# Altium schematic sheet MCU-BLE.SchDoc
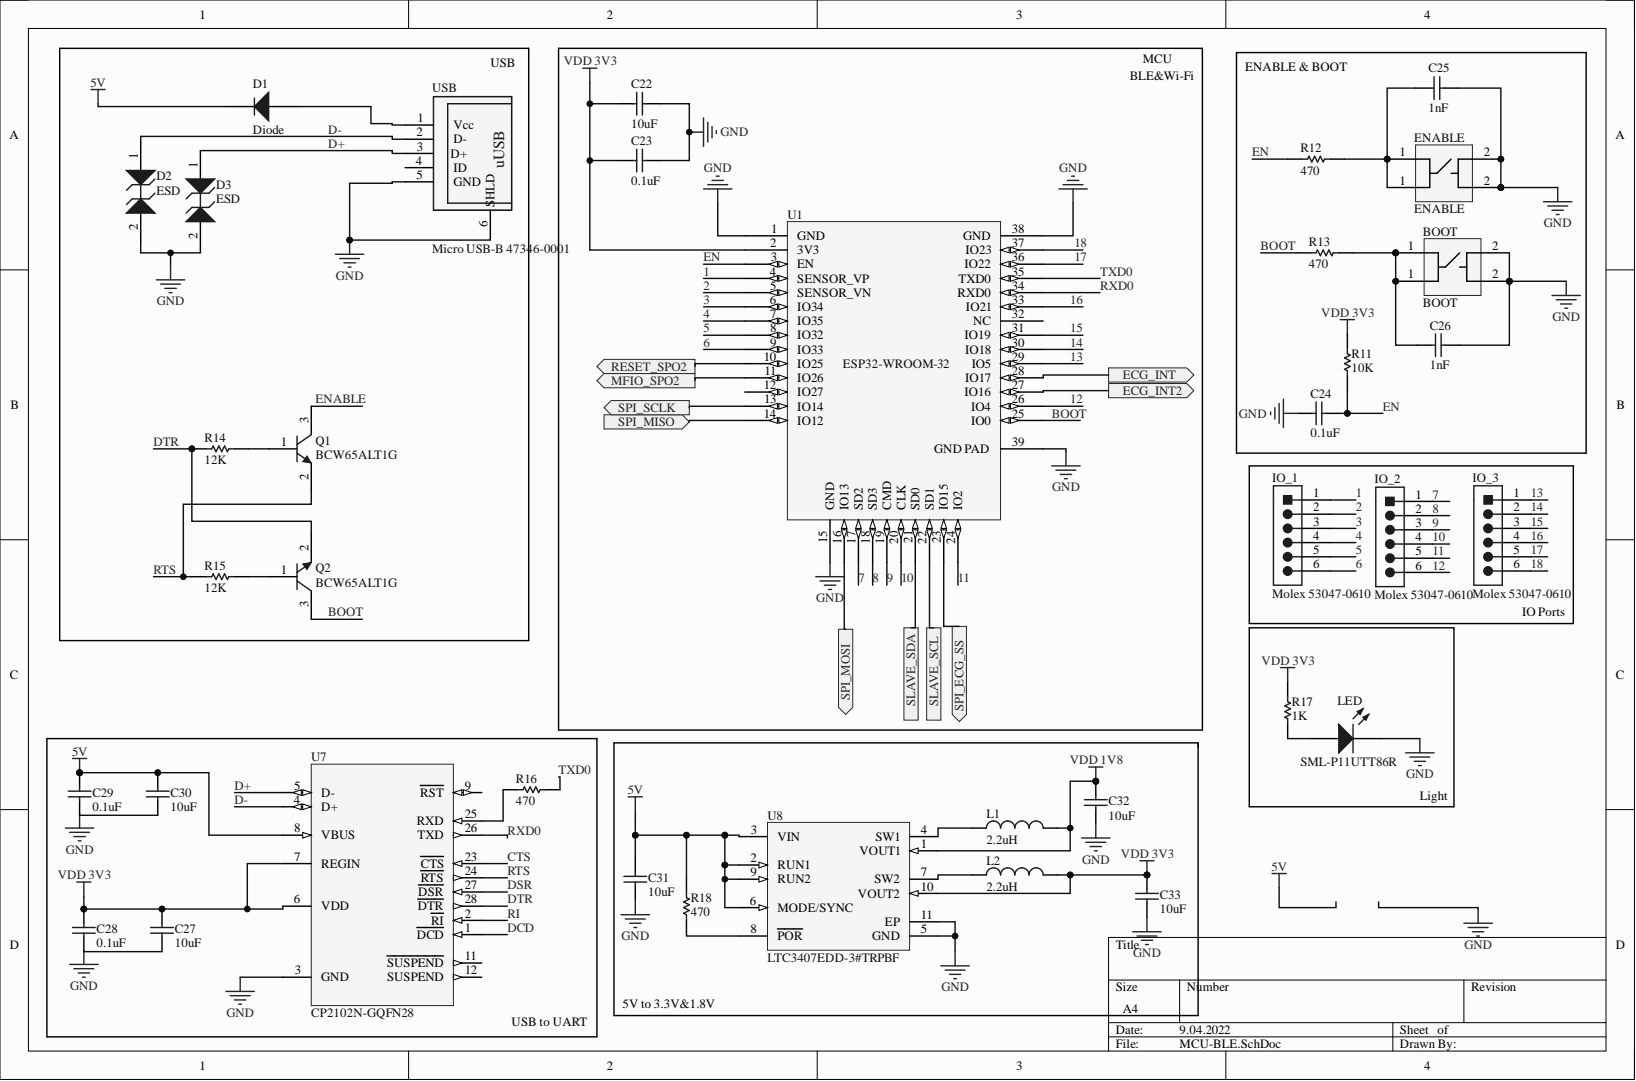
[diagram p0: MCU-BLE.SchDoc]

## Parts
BOOT  BOOT  [EVPAAxxxx]
C22  10uF  [CAPC1005X55X25ML05T10]
C23  0.1uF  [CAPC1005X55X25ML05T10]
C24  0.1uF  [CAPC1005X55X25ML05T10]
C25  1nF  [CAPC1005X55X25ML05T10]
C26  1nF  [CAPC1005X55X25ML05T10]
C27  10uF  [CAPC1005X55X25ML05T10]
C28  0.1uF  [CAPC1005X55X25ML05T10]
C29  0.1uF  [CAPC1005X55X25ML05T10]
C30  10uF  [CAPC1005X55X25ML05T10]
C31  10uF  [CAPC1005X55X25ML05T10]
C32  10uF  [CAPC1005X55X25ML05T10]
C33  10uF  [CAPC1005X55X25ML05T10]
D1  Diode  [SOD123]
D2  ESD  [ONSC-X3DFN-2-152AF-01_V]
D3  ESD  [ONSC-X3DFN-2-152AF-01_V]
ENABLE  ENABLE  [EVPAAxxxx]
IO_1  Molex 53047-0610  [PCB-k88o04xi12pf740xxbqc-1]
IO_2  Molex 53047-0610  [PCB-k88o04xi12pf740xxbqc-1]
IO_3  Molex 53047-0610  [PCB-k88o04xi12pf740xxbqc-1]
L1  2.2uH  [INDC2520X100X60NL20T20]
L2  2.2uH  [INDC2520X100X60NL20T20]
LED  SML-P11UTT86R  [PCB-kuzx22lkq8clwz8pgbid-1]
Q1  BCW65ALT1G  [ONSC-SOT-23-3-318-08_V]
Q2  BCW65ALT1G  [ONSC-SOT-23-3-318-08_V]
R11  10K  [0402-RES]
R12  470  [0402-RES]
R13  470  [0402-RES]
R14  12K  [0402-RES]
R15  12K  [0402-RES]
R16  470  [0402-RES]
R17  1K  [0402-RES]
R18  470  [0402-RES]
U1  ESP32-WROOM-32  [PCB-5zylbdqiciw5qvsb5wqm-1]
U7  CP2102N-GQFN28  [SLAB-QFN-28-3125x3125TP_M]
U8  LTC3407EDD-3#TRPBF  [LT-DD-10_V]
USB  Micro USB-B 47346-0001  [PCB-h877dzqq3emidpzhp5vc-1]

## Ports
ECG_INT, ECG_INT2, MFIO_SPO2, RESET_SPO2, SLAVE_SCL, SLAVE_SDA, SPI_ECG_SS, SPI_MISO, SPI_MOSI, SPI_SCLK

## Nets
5V: C29.2 C30.2 C31.2 D1.2 R18.1 U7.8(VBUS) U8.2(RUN1) U8.3(VIN) U8.6(MODE/SYNC) U8.9(RUN2)
GND: BOOT.2 C22.1 C23.1 C24.2 C25.1 C26.1 C31.1 C32.1 C33.1 D2.2 D3.2 ENABLE.2 LED.1 U1.1(GND) U1.15(GND) U1.38(GND) U1.39(GND_PAD) U7.3(GND) U7.29 U8.5(GND) U8.11(EP) USB.5(GND) USB.6(SHLD)
VDD 1V8: C32.2 L1.2 U8.1(VOUT1)
VDD 3V3: C22.2 C23.2 C27.2 C28.2 C33.2 L2.2 R11.2 R17.1 U1.2(3V3) U7.6(VDD) U7.7(REGIN) U8.10(VOUT2)
1: IO_1.1 U1.4(SENSOR_VP)
2: IO_1.2 U1.5(SENSOR_VN)
3: IO_1.3 U1.6(IO34)
4: IO_1.4 U1.7(IO35)
5: IO_1.5 U1.8(IO32)
6: IO_1.6 U1.9(IO33)
7: IO_2.1 U1.17(SD2)
8: IO_2.2 U1.18(SD3)
9: IO_2.3 U1.19(CMD)
10: IO_2.4 U1.20(CLK)
11: IO_2.5 U1.24(IO2)
12: IO_2.6 U1.26(IO4)
13: IO_3.1 U1.29(IO5)
14: IO_3.2 U1.30(IO18)
15: IO_3.3 U1.31(IO19)
16: IO_3.4 U1.33(IO21)
17: IO_3.5 U1.36(IO22)
18: IO_3.6 U1.37(IO23)
BOOT: Q2.3 R13.2 U1.25(IO0)
CTS: U7.23(CTS)
D+: D3.1 U7.5(D-) USB.3(D+)
D-: D2.1 U7.4(D+) USB.2(D-)
DCD: U7.1(DCD)
DSR: U7.27(DSR)
DTR: Q2.2 R14.2 U7.28(DTR)
ECG_INT: U1.28(IO17) ; port ECG_INT
ECG_INT2: U1.27(IO16) ; port ECG_INT2
EN: C24.1 R11.1 R12.2 U1.3(EN)
ENABLE: Q1.3
MFIO_SPO2: U1.11(IO26) ; port MFIO_SPO2
RESET_SPO2: U1.10(IO25) ; port RESET_SPO2
RI: U7.2(RI)
RTS: Q1.2 R15.2 U7.24(RTS)
RXD0: U1.34(RXD0) U7.26(TXD)
SLAVE_SCL: U1.22(SD1) ; port SLAVE_SCL
SLAVE_SDA: U1.21(SD0) ; port SLAVE_SDA
SPI_ECG_SS: U1.23(IO15) ; port SPI_ECG_SS
SPI_MISO: U1.14(IO12) ; port SPI_MISO
SPI_MOSI: U1.16(IO13) ; port SPI_MOSI
SPI_SCLK: U1.13(IO14) ; port SPI_SCLK
TXD0: R16.1 U1.35(TXD0)
NetBOOT_1: BOOT.1 C26.2 R13.1
NetC25_2: C25.2 ENABLE.1 R12.1
NetC27_1: C27.1 C28.1
NetC29_1: C29.1 C30.1
NetD1_1: D1.1 USB.1(Vcc)
NetL1_1: L1.1 U8.4(SW1)
NetL2_1: L2.1 U8.7(SW2)
NetLED_2: LED.2 R17.2
NetQ1_1: Q1.1 R14.1
NetQ2_1: Q2.1 R15.1
NetR16_2: R16.2 U7.25(RXD)
NetR18_2: R18.2 U8.8(POR)

Not connected: U1 12(IO27) 32(NC); U7 9(RST) 11(SUSPEND) 12(SUSPEND); USB 4(ID)

## Library data of the parts
BOOT ENABLE = CMP-114dda18f992ce8a-3: Switch Tactile N.O. SPST Rectangular Button J-Bend 0.02A 15VDC 3.5N SMD Embossed T/R; Manufacturer Part Number: EVP-AA602W; Component Kind: Standard; Library Name: CommunityVault; Lifecycle Status: Not Listed by Manufacturer; Mounting Style: Surface Mount; OctopartID: 114dda18f992ce8a; Packaging: Tape & Reel (TR); REACH SVHC Compliance: No SVHC; Size-Length: 3.50 mm
C22-C33 = CMP-13045642-2: Multilayer Ceramic Capacitors MLCC - SMD/SMT 1.0UF 6.3V 20% 0402; Manufacturer Part Number: GRM155R70J105MA12D; Capacitance: 1e-06 F; Dielectric Characteristic: X7R; Capacitance Tolerance: ±20%; Component Kind: Standard; Library Name: CommunityVault; Lifecycle Status: Active; Octopart Url: https://octopart.com/grm155r70j105ma12d-murata-23261089; OctopartID: 2dc3e5abe704f204; RoHS: Compliant; Size-Length: 0.001 m; Size-Thickness: 0.0005 m; Size-Width: 0.0005 m; Voltage Rating (DC): 6.3 V
D1 = CMP-815630-6: 1N5819HW Series 40 V 1 A Surface Mount Schottky Barrier Rectifier - SOD-123; Manufacturer Part Number: 1N5819HW-7-F; Capacitance: 110 pF; Current Rating: 1.00 A; Lead-Free Status: Lead Free; Polarity: Standard; Voltage Rating (DC): 40.0 V; Case/Package: SOD-123; Component Kind: Standard; Library Name: CommunityVault; Lifecycle Status: Active; Number of Pins: 2; Octopart URL: http://octopart.com/1n5819hw-7-f-diodes+inc.-323197; OctopartID: e83e2130232537f9; Packaging: Cut Tape (CT), Tape & Reel (TR); REACH SVHC Compliance: No SVHC; RoHS: Compliant
D2 D3 = CMP-4505820-2: Transient Voltage Suppressor, Micro-Packaged Diode for ESD Protection, 2-Pin X3DFN, Pb-Free, Tape and Reel; Manufacturer Part Number: ESD7481MUT5G; Lead-Free Status: Lead Free; Operating Voltage: 3.3 V; Case/Package: X3DFN; Component Kind: Standard; Halogen Free Status: Halogen Free; Library Name: CommunityVault; Lifecycle Status: Active; Number of Pins: 2; Octopart Url: https://octopart.com/esd7481mut5g-on+semiconductor-24638080; OctopartID: 409a88e7977c9f5d; RoHS: Compliant
IO_1-IO_3 = CMP-dda714a9c1e90260-4: 1.25mm (.049") Pitch PicoBlade Wire-to-Board Header, Vertical, with Friction Lock, 6 Circuits; Component Kind: Standard; Library Name: FavoritesLibrary
L1 L2 = CMP-227de9c45717fdba-1: Ind Power Chip Shielded Multi-Layer 2.2uH 20% 1MHz Ferrite 1.3A 1008 T/R; Manufacturer: Bourns; Manufacturer Part Number: CVH252009-2R2M; Current Rating: 1.30 A; Self Resonant Frequency: 40.0 MHz; Component Kind: Standard; Library Name: CommunityVault; Octopart URL: https://octopart.com/cvh252009-2r2m-bourns-45305868; OctopartID: 227de9c45717fdba; REACH SVHC Compliance: No SVHC; RoHS: Compliant
LED = CMP-2156ea0ff90ffe91-2: LED Uni-Color Red Chip LED 2-Pin 0402 T/R; Forward Voltage: 1.80 V; Component Kind: Standard; Library Name: CommunityVault
Q1 Q2 = CMP-860784-2: General Purpose Transistor, NPN Silicon, 3-Pin SOT-23, Pb-Free, Tape and Reel; Current Rating: 0.8 A; Lead-Free Status: Lead Free; Voltage Rating (DC): 32.0 V; Case/Package: SOT-23; Component Kind: Standard; Library Name: CommunityVault; Lifecycle Status: Active; Manufacturer Part Number: BCW65ALT1G; Mounting Style: Surface Mount; Number of Pins: 3; Octopart Url: https://octopart.com/bcw65alt1g-on+semiconductor-1257889; OctopartID: d74a1e53c38d088d; Packaging: Tape; Polarity: NPN; RoHS: Compliant
R11-R18 = CMP-4843210-4: Res Thick Film 0402 10K Ohm 1% 0.1W(1/10W) ±100ppm/°C Molded SMD Punched Carrier T/R; Manufacturer Part Number: ERJ-2RKF1002X; Lead-Free Status: Lead Free; Power Rating: 100 mW; Resistance: 10.0 kΩ; Voltage Rating (DC): 50.0 V; Case/Package: 0402; Component Kind: Standard; Library Name: CommunityVault; Lifecycle Status: Not Listed by Manufacturer; Mounting Style: Surface Mount; Number of Pins: 2; Octopart Url: http://octopart.com/erj-2rkf1002x-panasonic-55411477; OctopartID: c00e0a49667d1fc9; Packaging: Tape & Reel (TR), Cut Tape (CT); REACH SVHC Compliance: No SVHC; Resistance Tolerance: ±1%; RoHS: Compliant; Seller Landing  Url: https://octopart-clicks.com/click/track?country=US&ak=8157f166&sig=003b86e&sid=1106&ppid=55411477&vpid=188035435&ct=offers; Size-Height: 350 µm; Size-Length: 1.00 mm; Size-Width: 500 µm
U1 = CMP-ba1676706f1765d1-19: WiFi Modules (802.11) SMD Module, ESP32-D0WDQ6, 32Mbits SPI flash, UART Mode; Component Kind: Standard; Library Name: CommunityVault; Manufacturer Part Number: ESP32-WROOM-32; Mounting Style: Surface Mount; OctopartID: ba1676706f1765d1
U7 = CMP-f81a3562078427dd-5: USB Bridge, USB to UART USB 2.0 UART Interface 28-QFN (5x5); Manufacturer Part Number: CP2102N-A01-GQFN28; Supply Voltage (DC): 3.00 V (min); Component Kind: Standard; Library Name: CommunityVault; Octopart URL: http://octopart.com/cp2102n-a01-gqfn28-silicon+labs-74636024; OctopartID: f81a3562078427dd; RoHS: Compliant
U8 = CMP-1486943-2: Dual Synchronous 800 mA, 2.25 MHz Step-Down DC/DC Regulator, 10-pin SON (DD-10), -40 to 85 degC, Pb-Free, Tape and Reel; Component Kind: Standard; Library Name: CommunityVault; Manufacturer Part Number: LTC3407EDD-3#TRPBF; Mounting Style: Surface Mount; Octopart Url: https://octopart.com/ltc3407edd-3%23trpbf-linear+technology-13133438; OctopartID: 0be9c22b48f1d42e; RoHS: Compliant
USB = CMP-79f5b1062168a632-4: Conn Micro USB Type B RCP 5 POS 0.65mm Solder RA SMD 5 Terminal 1 Port Embossed T/R; Manufacturer Part Number: 47346-1001; Gender: Female; Number of Circuits: 5; Component Kind: Standard; Contact Material: Copper Alloy; Contact Plating: Gold; Halogen Free Status: Low Halogen; Library Name: CommunityVault; Lifecycle Status: Active; Mounting Style: Surface Mount, Horizontal; Octopart URL: https://octopart.com/47346-1001-molex-33127931; OctopartID: 79f5b1062168a632; Orientation: Right Angle; Packaging: Tape & Reel (TR); REACH SVHC Compliance: No SVHC; RoHS: Compliant
